# Altium schematic sheet 02_Simple_ARM.SchDoc
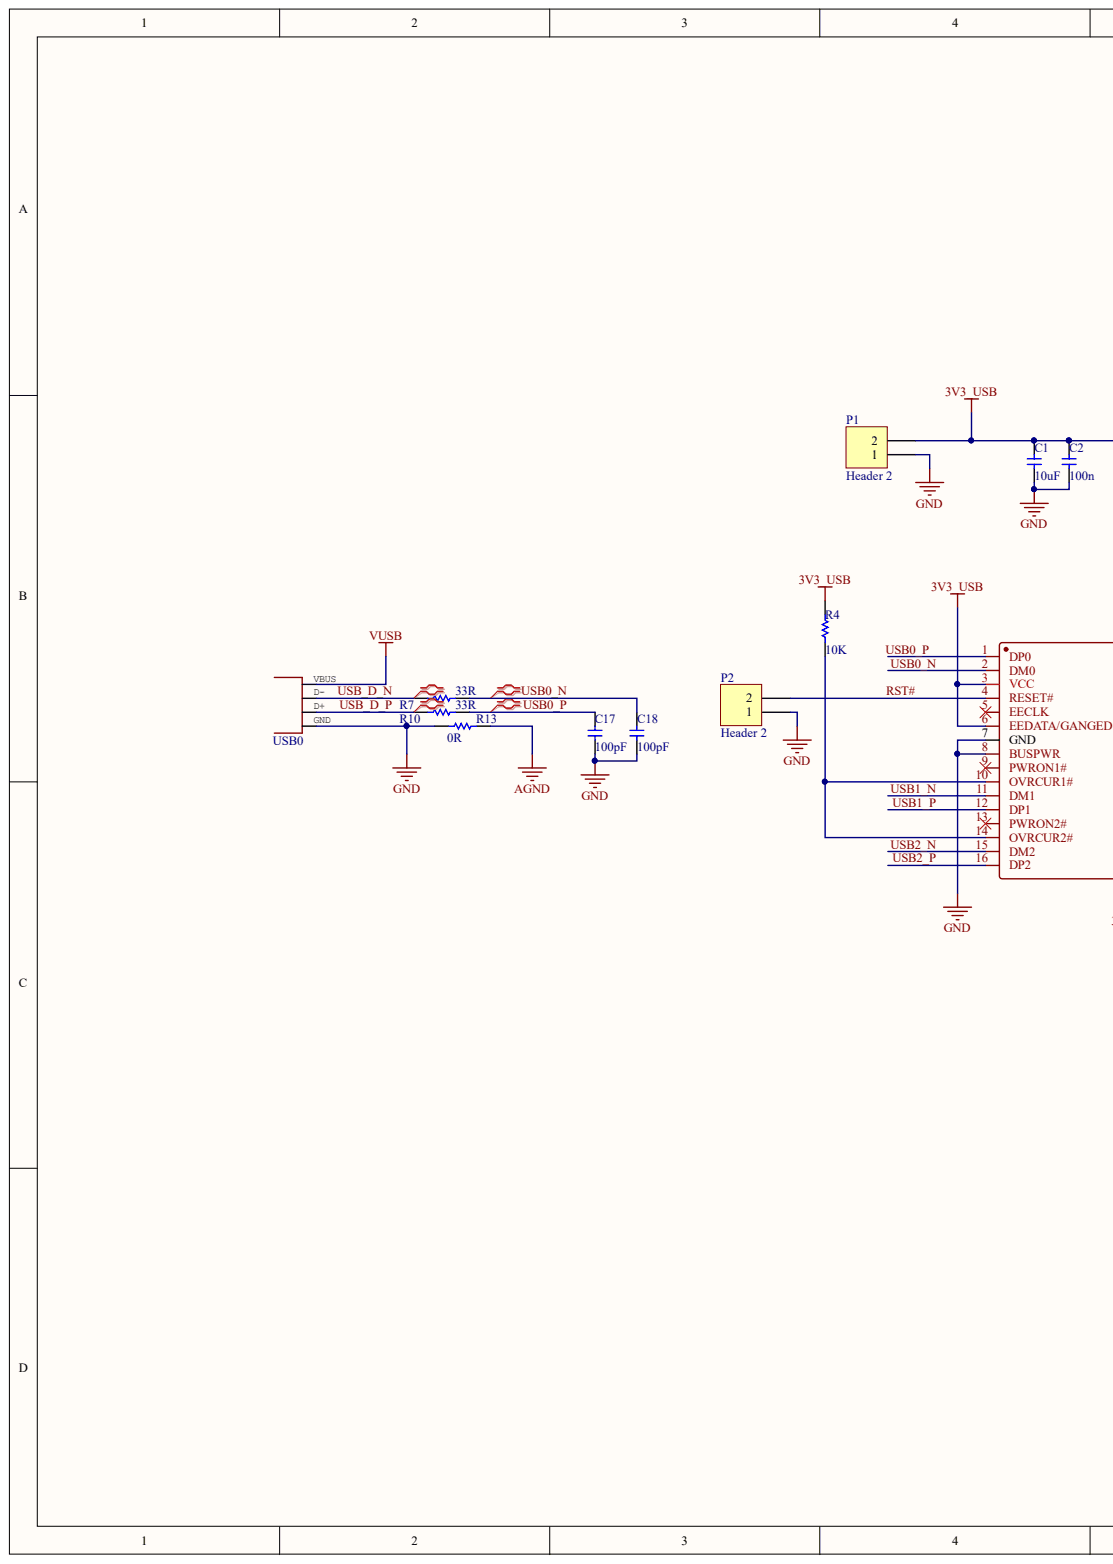
[diagram p0: 02_Simple_ARM.SchDoc, left]
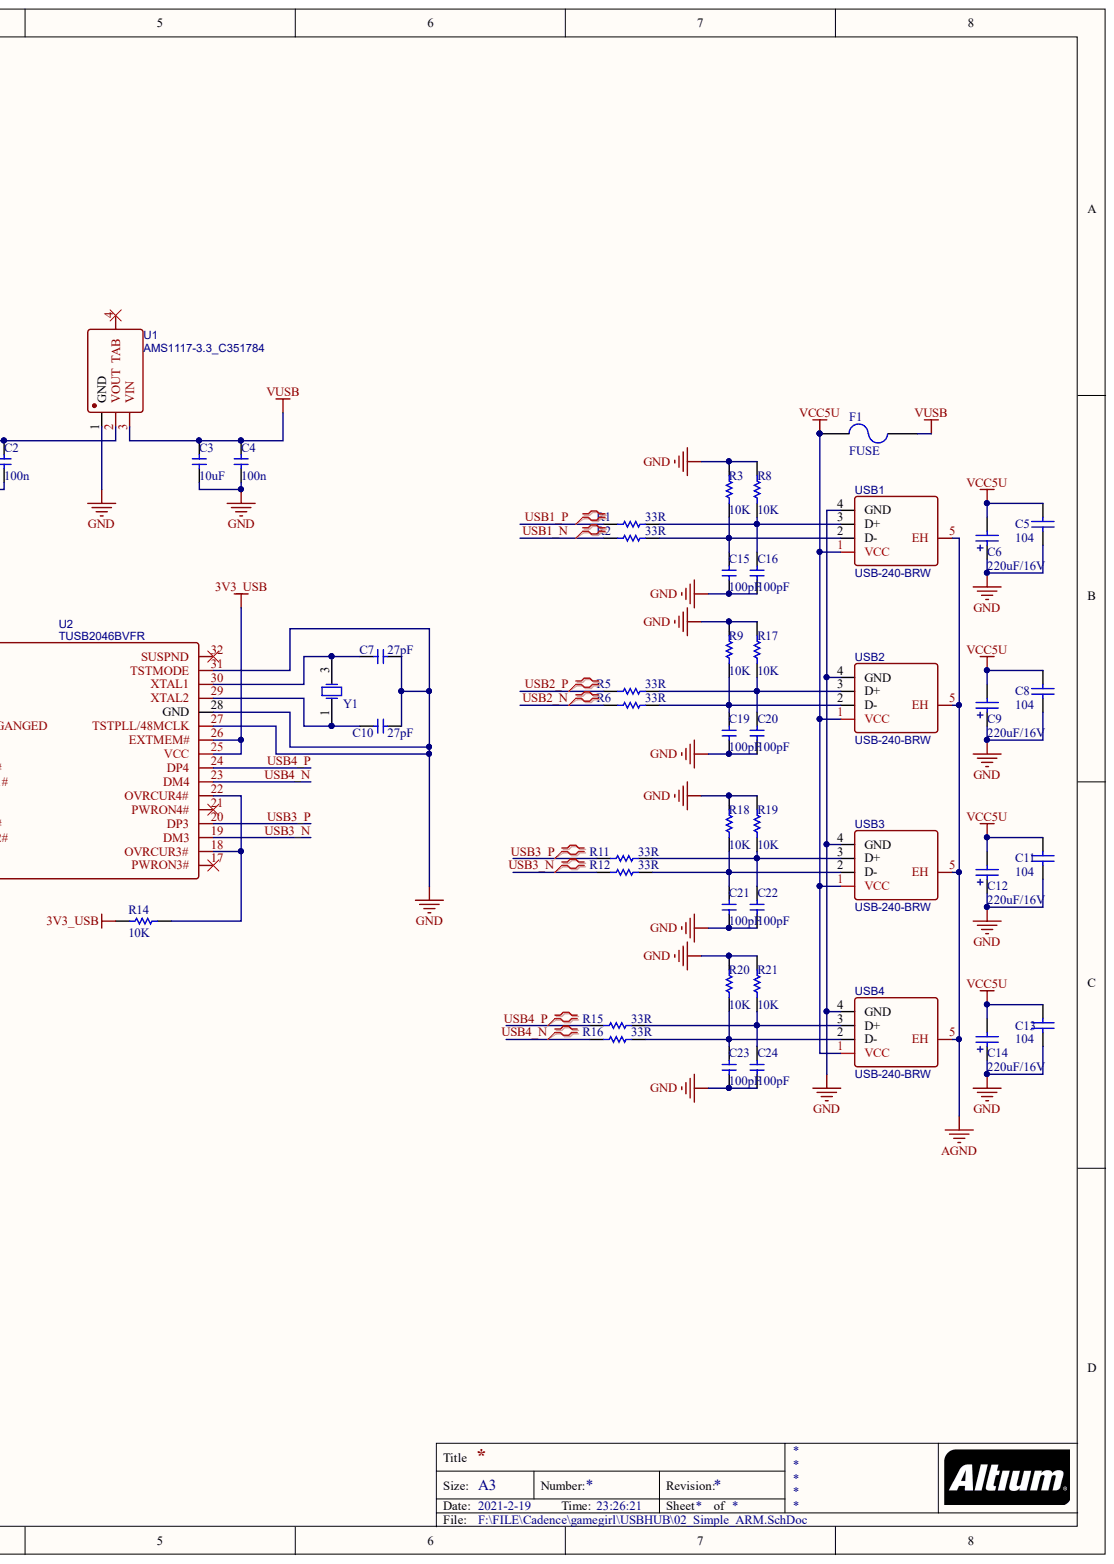
[diagram p1: 02_Simple_ARM.SchDoc, right]

## Parts
C1  value 10uF  [0603]  (hidden comment: 0603)
C2  value 100n  [0603]  (hidden comment: 0603)
C3  value 10uF  [0603]  (hidden comment: 0603)
C4  value 100n  [0603]  (hidden comment: 0603)
C5  value 104  [0603]  (hidden comment: Cap1)
C6  value 220uF/16V  [0805]  (hidden comment: Cap_Pol)
C7  value 27pF  [0603]  (hidden comment: 0603)
C8  value 104  [0603]  (hidden comment: Cap1)
C9  value 220uF/16V  [0805]  (hidden comment: Cap_Pol)
C10  value 27pF  [0603]  (hidden comment: 0603)
C11  value 104  [0603]  (hidden comment: Cap1)
C12  value 220uF/16V  [0805]  (hidden comment: Cap_Pol)
C13  value 104  [0603]  (hidden comment: Cap1)
C14  value 220uF/16V  [0805]  (hidden comment: Cap_Pol)
C15  value 100pF  [0603]  (hidden comment: 0603)
C16  value 100pF  [0603]  (hidden comment: 0603)
C17  value 100pF  [0603]  (hidden comment: 0603)
C18  value 100pF  [0603]  (hidden comment: 0603)
C19  value 100pF  [0603]  (hidden comment: 0603)
C20  value 100pF  [0603]  (hidden comment: 0603)
C21  value 100pF  [0603]  (hidden comment: 0603)
C22  value 100pF  [0603]  (hidden comment: 0603)
C23  value 100pF  [0603]  (hidden comment: 0603)
C24  value 100pF  [0603]  (hidden comment: 0603)
F1  FUSE  [FUSE_1206]
P1  Header 2  [HDR1X2]
P2  Header 2  [HDR1X2]
R1  33R  [0603]
R2  33R  [0603]
R3  10K  [0603]
R4  10K  [0603]
R5  33R  [0603]
R6  33R  [0603]
R7  33R  [0603]
R8  10K  [0603]
R9  10K  [0603]
R10  33R  [0603]
R11  33R  [0603]
R12  33R  [0603]
R13  0R  [0603]
R14  10K  [0603]
R15  33R  [0603]
R16  33R  [0603]
R17  10K  [0603]
R18  10K  [0603]
R19  10K  [0603]
R20  10K  [0603]
R21  10K  [0603]
U1  AMS1117-3.3_C351784  [SOT-223-3_L6.5-W3.4-P2.30-LS7.0-BR]
U2  TUSB2046BVFR  [LQFP-32_L7.0-W7.0-P0.80-LS9.0-TL]
USB0  USBA-PTH  [USB-A-F-PTH]  (value not drawn)
USB1  USB-240-BRW  [USB-TH_USB-265-BRY]
USB2  USB-240-BRW  [USB-TH_USB-265-BRY]
USB3  USB-240-BRW  [USB-TH_USB-265-BRY]
USB4  USB-240-BRW  [USB-TH_USB-265-BRY]
Y1  12MHZ 20PF 10PPM  [SMD-3225_4P]  (value not drawn)

## Nets
3V3_USB: C1.1 C2.1 P1.2 R4.1 R14.1 U1.2(VOUT) U2.3(VCC) U2.6(EEDATA/GANGED) U2.25(VCC) U2.26(EXTMEM#)
AGND: R13.1 USB1.5(EH) USB2.5(EH) USB3.5(EH) USB4.5(EH)
GND: C1.2 C2.2 C3.2 C4.2 C5.1 C6.1 C7.2 C8.1 C9.1 C10.2 C11.1 C12.1 C13.1 C14.1 C15.2 C16.2 C17.2 C18.2 C19.2 C20.2 C21.2 C22.2 C23.2 C24.2 P1.1 P2.1 R3.1 R8.1 R9.1 R13.2 R17.1 R18.1 R19.1 R20.1 R21.1 U1.1(GND) U2.7(GND) U2.8(BUSPWR) U2.27(TSTPLL/48MCLK) U2.28(GND) U2.31(TSTMODE) USB0.GND USB1.4(GND) USB2.4(GND) USB3.4(GND) USB4.4(GND)
VCC5U: C5.2 C6.2 C8.2 C9.2 C11.2 C12.2 C13.2 C14.2 F1.1 USB1.1(VCC) USB2.1(VCC) USB3.1(VCC) USB4.1(VCC)
VUSB: C3.1 C4.1 F1.2 U1.3(VIN) USB0.VBUS
RST#: P2.2 U2.4(RESET#)
USB0_N: C18.1 R7.1 U2.2(DM0)
USB0_P: C17.1 R10.1 U2.1(DP0)
USB1_N: R2.2 U2.11(DM1)
USB1_P: R1.2 U2.12(DP1)
USB2_N: R6.2 U2.15(DM2)
USB2_P: R5.2 U2.16(DP2)
USB3_N: R12.2 U2.19(DM3)
USB3_P: R11.2 U2.20(DP3)
USB4_N: R16.2 U2.23(DM4)
USB4_P: R15.2 U2.24(DP4)
USB_D_N: R7.2 USB0.D-
USB_D_P: R10.2 USB0.D+
NetC7_1: C7.1 U2.30(XTAL1) Y1.3
NetC10_1: C10.1 U2.29(XTAL2) Y1.1
NetC15_1: C15.1 R2.1 R3.2 USB1.2(D-)
NetC16_1: C16.1 R1.1 R8.2 USB1.3(D+)
NetC19_1: C19.1 R6.1 R9.2 USB2.2(D-)
NetC20_1: C20.1 R5.1 R17.2 USB2.3(D+)
NetC21_1: C21.1 R12.1 R18.2 USB3.2(D-)
NetC22_1: C22.1 R11.1 R19.2 USB3.3(D+)
NetC23_1: C23.1 R16.1 R20.2 USB4.2(D-)
NetC24_1: C24.1 R15.1 R21.2 USB4.3(D+)
NetR4_2: R4.2 U2.10(OVRCUR1#) U2.14(OVRCUR2#)
NetR14_2: R14.2 U2.18(OVRCUR3#) U2.22(OVRCUR4#)

Not connected: U1 4(TAB); U2 5(EECLK) 9(PWRON1#) 13(PWRON2#) 17(PWRON3#) 21(PWRON4#) 32(SUSPND)

## Library data of the parts
C1-C4 C15-C24 = CAP_0603: 0603 footprint non-polorised capacitor; Component Kind: Standard; Library Name: Integrated Comp_lib.IntLib
C5 C8 C11 C13 = Cap1: Capacitor; Component Kind: Standard; LatestRevisionDate: 17-Jul-2002; LatestRevisionNote: Re-released for DXP Platform.; PackageReference: RAD-0.3; Published: 8-Jun-2000; Library Name: weifengdq.IntLib; Publisher: Altium Limited
C6 C9 C12 C14 = Cap_Pol: Capacitor; Component Kind: Standard; LatestRevisionDate: 17-Jul-2002; LatestRevisionNote: Re-released for DXP Platform.; PackageReference: RAD-0.3; Published: 8-Jun-2000; Library Name: weifengdq.IntLib; Publisher: Altium Limited
C7 C10 = CAP_0805: 0805 footprint non-polarised capacitor; Component Kind: Standard; Library Name: Integrated Comp_lib.IntLib
P1 P2 = Header 2: Header, 2-Pin; Component Kind: Standard; LatestRevisionDate: 17-Jul-2002; LatestRevisionNote: Re-released for DXP Platform.; Library Name: Miscellaneous Connectors.IntLib; Signal Integrity: Connector; Publisher: Altium Limited
R1-R21 = RES: Component Kind: Standard; Library Name: 电阻.IntLib
U1 = AMS1117-3.3_C351784: BOM_Manufacturer: PUOLOP; Contributor: LCSC; BOM_Manufacturer Part: AMS1117-3.3; BOM_Supplier: LCSC; BOM_Supplier Part: C351784; Component Kind: Standard; spicePre: U; spiceSymbolName: AMS1117-3.3_C351784
U2 = TUSB2046BVFR: BOM_Manufacturer: Texas Instruments; Contributor: LCSC; SMT Type: Extend; BOM_JLCPCB SMD: Yes; BOM_Manufacturer Part: TUSB2046BVFR; BOM_Supplier: LCSC; BOM_Supplier Part: C167642; Component Kind: Standard; spicePre: U; spiceSymbolName: TUSB2046BVFR
USB1-USB4 = USB-240-BRW: BOM_Manufacturer: XUNPU; Contributor: LCSC; BOM_Manufacturer Part: USB-240-BRW; BOM_Supplier: LCSC; BOM_Supplier Part: C720530; Component Kind: Standard; spicePre: U; spiceSymbolName: USB-240-BRW
Y1 = SMD-3225_4P  12MHZ 20PF 10PPM: 贴片晶振; Component Kind: Standard; ComponentLink1Description: 供应商链接; ComponentLink1URL: http://www.szlcsc.com/product/details_9522.html; Library Name: CrystalOscillator.SchLib; Package: SMD-3225_4P; Supplier: LC; SuppliersPartNumber: C9002
F1 = FUSE: Component Kind: Standard; Library Name: 保险丝.IntLib
USB0 = USBA-PTH: Component Kind: Standard; Library Name: lib.SchLib; USB: USB
